# Altium schematic sheet ModelT_MainBoard.SchDoc
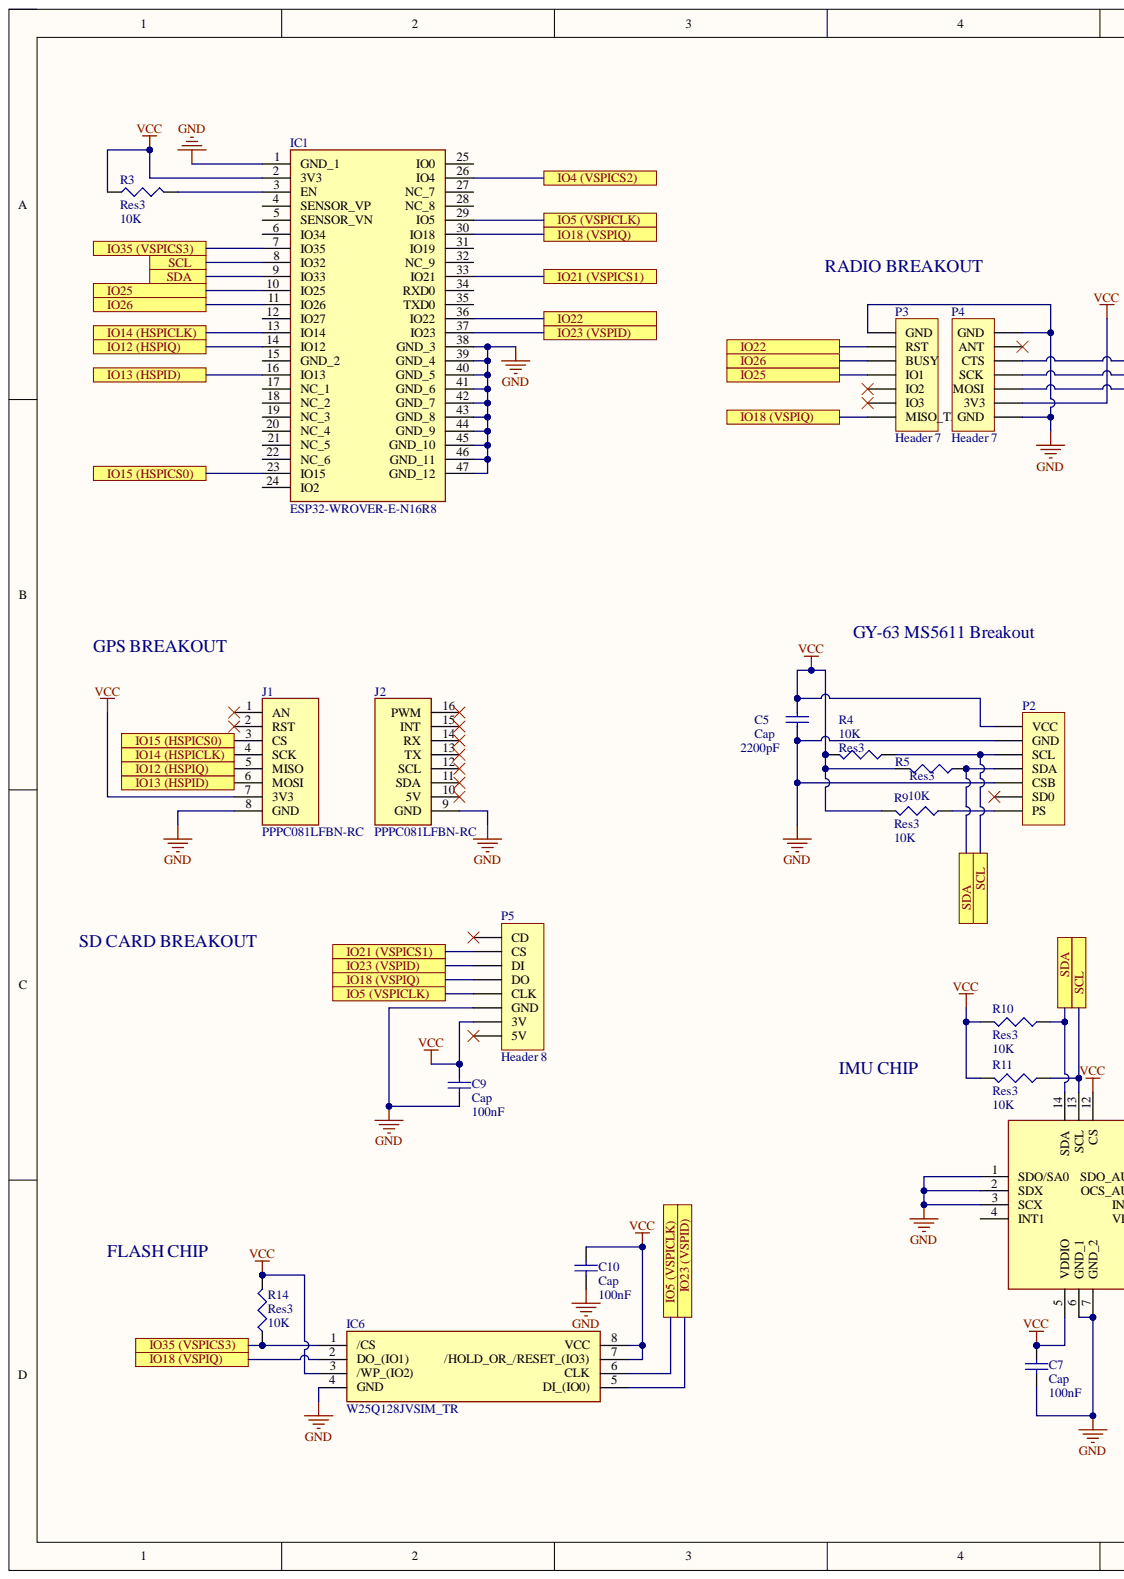
[diagram p0: ModelT_MainBoard.SchDoc, left]
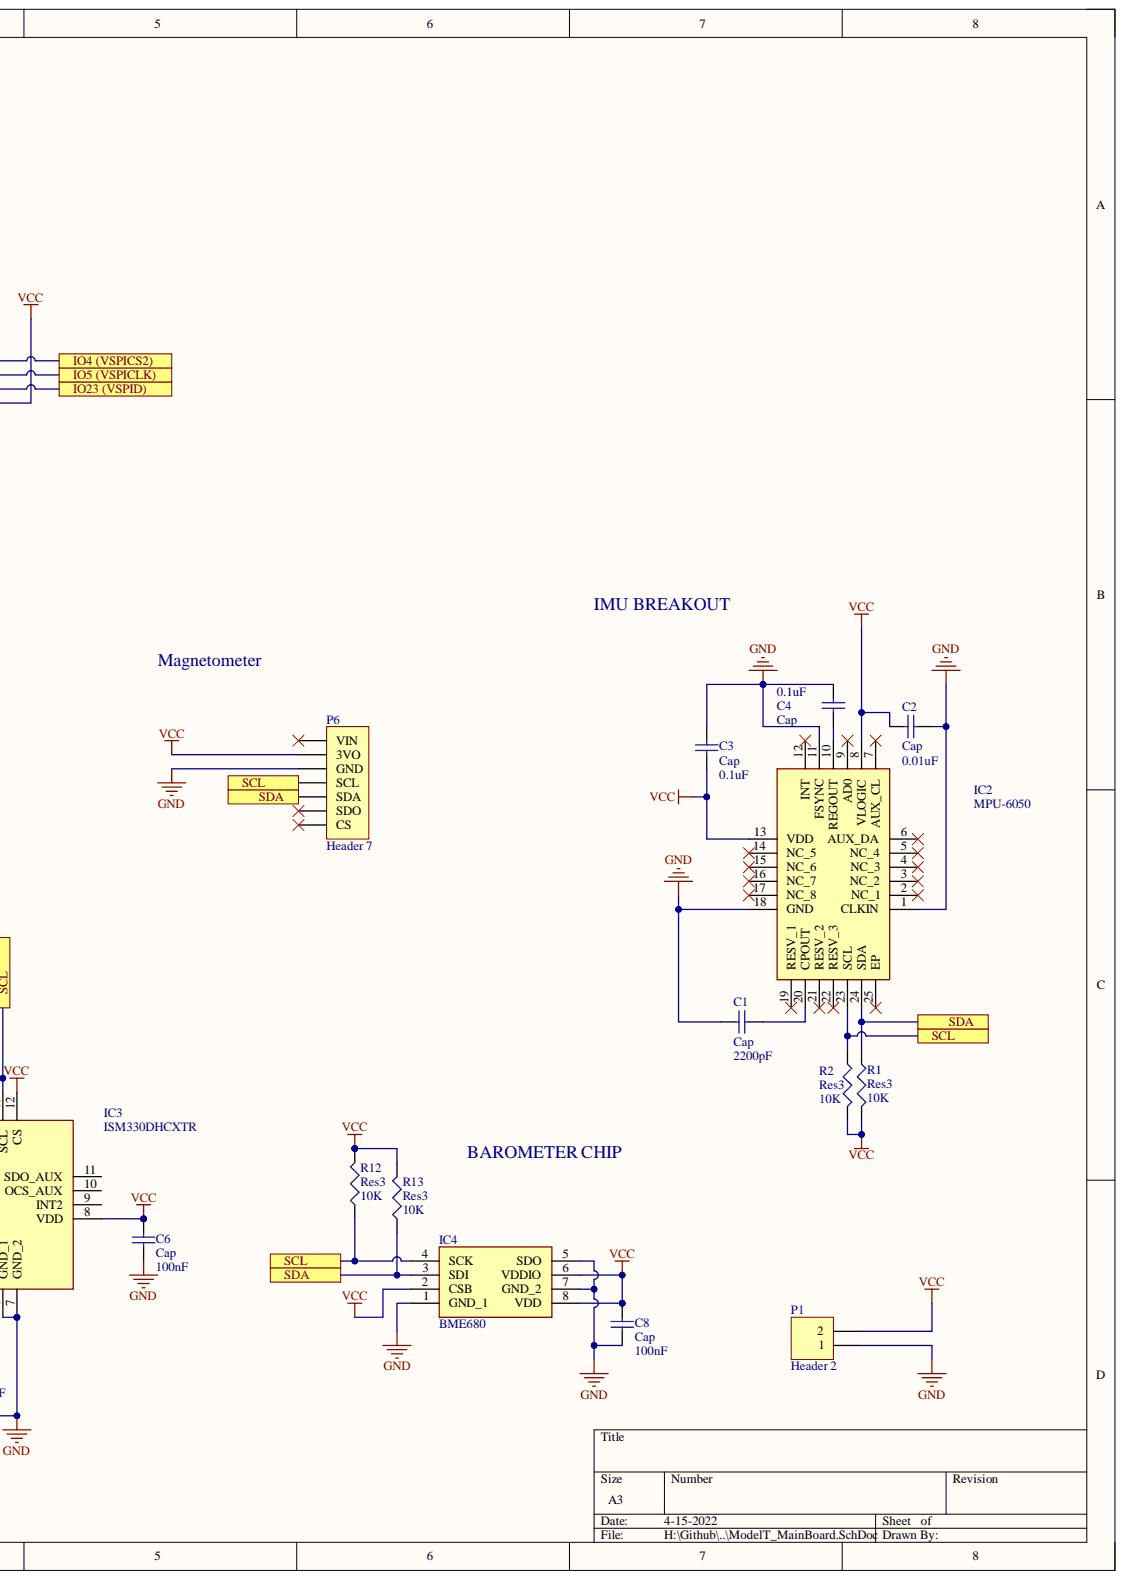
[diagram p1: ModelT_MainBoard.SchDoc, right]

## Parts
C1  Cap  value 2200pF  [RAD-0.3]
C2  Cap  value 0.01uF  [RAD-0.3]
C3  Cap  value 0.1uF  [RAD-0.3]
C4  Cap  value 0.1uF  [RAD-0.3]
C5  Cap  value 2200pF  [RAD-0.3]
C6  Cap  value 100nF  [RAD-0.3]
C7  Cap  value 100nF  [RAD-0.3]
C8  Cap  value 100nF  [RAD-0.3]
C9  Cap  value 100nF  [RAD-0.3]
C10  Cap  value 100nF  [RAD-0.3]
IC1  ESP32-WROVER-E-N16R8  [ESP32WROVEREN16R8]
IC2  MPU-6050  [MPU6050]
IC3  ISM330DHCXTR  [LGA-14(2.5X3X0.86)]
IC4  BME680  [BME680]
IC6  W25Q128JVSIM_TR  [SOIC127P790X216-8N]
J1  PPPC081LFBN-RC  [RHDR8W80P0X254_1X8_2082X254X850P]
J2  PPPC081LFBN-RC  [RHDR8W80P0X254_1X8_2082X254X850P]
P1  Header 2  [HDR1X2]
P2  GY-63 MS5611 Breakout  [HDR1X7]
P3  Header 7  [HDR1X7]
P4  Header 7  [HDR1X7]
P5  Header 8  [HDR1X8]
P6  Header 7  [HDR1X7]
R1  Res3  value 10K  [J1-0603]
R2  Res3  value 10K  [J1-0603]
R3  Res3  value 10K  [J1-0603]
R4  Res3  value 10K  [J1-0603]
R5  Res3  value 10K  [J1-0603]
R9  Res3  value 10K  [J1-0603]
R10  Res3  value 10K  [J1-0603]
R11  Res3  value 10K  [J1-0603]
R12  Res3  value 10K  [J1-0603]
R13  Res3  value 10K  [J1-0603]
R14  Res3  value 10K  [J1-0603]

## Ports
IO4 (VSPICS2), IO5 (VSPICLK), IO12 (HSPIQ), IO13 (HSPID), IO14 (HSPICLK), IO15 (HSPICS0), IO18 (VSPIQ), IO21 (VSPICS1), IO22, IO23 (VSPID), IO25, IO26, IO35 (VSPICS3), SCL, SDA

## Nets
GND: C1.2 C2.2 C3.1 C4.1 C5.2 C6.1 C7.1 C8.1 C9.1 C10.1 IC1.1(GND_1) IC1.38(GND_3) IC1.39(GND_4) IC1.40(GND_5) IC1.41(GND_6) IC1.42(GND_7) IC1.43(GND_8) IC1.44(GND_9) IC1.45(GND_10) IC1.46(GND_11) IC1.47(GND_12) IC2.1(CLKIN) IC2.11(FSYNC) IC2.18(GND) IC3.1(SDO/SA0) IC3.2(SDX) IC3.3(SCX) IC3.6(GND_1) IC3.7(GND_2) IC4.1(GND_1) IC4.5(SDO) IC4.7(GND_2) IC6.4(GND) J1.8(GND) J2.9(GND) P1.1 P2.2(GND) P2.5(CSB) P3.1(GND) P4.8(GND) P4.14(GND) P5.6(GND) P6.3(GND)
VCC: C2.1 C3.2 C5.1 C6.2 C7.2 C8.2 C9.2 C10.2 IC1.2(3V3) IC2.8(VLOGIC) IC2.13(VDD) IC3.5(VDDIO) IC3.8(VDD) IC3.12(CS) IC4.2(CSB) IC4.6(VDDIO) IC4.8(VDD) IC6.3(/WP_(IO2)) IC6.7(/HOLD_OR_/RESET_(IO3)) IC6.8(VCC) J1.7(3V3) P1.2 P2.1(VCC) P4.9(3V3) P5.7(3V) P6.2(3VO) R1.2 R2.2 R3.1 R4.2 R5.2 R9.2 R10.2 R11.2 R12.2 R13.2 R14.2
IO4 (VSPICS2): IC1.26(IO4) P4.12(CTS) ; port IO4 (VSPICS2)
IO5 (VSPICLK): IC1.29(IO5) IC6.6(CLK) P4.11(SCK) P5.5(CLK) ; port IO5 (VSPICLK)
IO12 (HSPIQ): IC1.14(IO12) J1.5(MISO) ; port IO12 (HSPIQ)
IO13 (HSPID): IC1.16(IO13) J1.6(MOSI) ; port IO13 (HSPID)
IO14 (HSPICLK): IC1.13(IO14) J1.4(SCK) ; port IO14 (HSPICLK)
IO15 (HSPICS0): IC1.23(IO15) J1.3(CS) ; port IO15 (HSPICS0)
IO18 (VSPIQ): IC1.30(IO18) IC6.2(DO_(IO1)) P3.7 P5.4(DO) ; port IO18 (VSPIQ)
IO21 (VSPICS1): IC1.33(IO21) P5.2(CS) ; port IO21 (VSPICS1)
IO22: IC1.36(IO22) P3.2(RST) ; port IO22
IO23 (VSPID): IC1.37(IO23) IC6.5(DI_(IO0)) P4.10(MOSI) P5.3(DI) ; port IO23 (VSPID)
IO25: IC1.10(IO25) P3.4(IO1) ; port IO25
IO26: IC1.11(IO26) P3.3 ; port IO26
IO35 (VSPICS3): IC1.7(IO35) IC6.1(/CS) R14.1 ; port IO35 (VSPICS3)
SCL: IC1.8(IO32) IC2.23(SCL) IC3.13(SCL) IC4.4(SCK) P2.3(SCL) P6.4(SCL) R2.1 R4.1 R11.1 R12.1 ; port SCL
SDA: IC1.9(IO33) IC2.24(SDA) IC3.14(SDA) IC4.3(SDI) P2.4(SDA) P6.5(SDA) R1.1 R5.1 R10.1 R13.1 ; port SDA
NetC1_1: C1.1 IC2.20(CPOUT)
NetC4_2: C4.2 IC2.10(REGOUT)
NetIC1_3: IC1.3(EN) R3.2
NetP2_7: P2.7(PS) R9.1

Not connected: IC1 4(SENSOR_VP) 5(SENSOR_VN) 6(IO34) 12(IO27) 15(GND_2) 17(NC_1) 18(NC_2) 19(NC_3) 20(NC_4) 21(NC_5) 22(NC_6) 24(IO2) 25(IO0) 27(NC_7) 28(NC_8) 31(IO19) 32(NC_9) 34(RXD0) 35(TXD0); IC2 2(NC_1) 3(NC_2) 4(NC_3) 5(NC_4) 6(AUX_DA) 7(AUX_CL) 9(AD0) 12(INT) 14(NC_5) 15(NC_6) 16(NC_7) 17(NC_8) 19(RESV_1) 21(RESV_2) 22(RESV_3) 25(EP); IC3 4(INT1) 9(INT2) 10(OCS_AUX) 11(SDO_AUX); J1 1(AN) 2(RST); J2 10(5V) 11(SDA) 12(SCL) 13(TX) 14(RX) 15(INT) 16(PWM); P2 6(SD0); P3 5(IO2) 6(IO3); P4 13(ANT); P5 1(CD) 8(5V); P6 1(VIN) 6(SDO) 7(CS)

## Library data of the parts
C1 C3-C10 = Cap: Capacitor; Component Kind: Standard; LatestRevisionDate: 17-Jul-2002; LatestRevisionNote: Re-released for DXP Platform.; Library Name: Miscellaneous Devices.IntLib; PackageDescription: Radial Cap, Thru-Hole; 2 Leads; 0.3 in Pin Spacing; PackageReference: RAD-0.3; Published: 8-Jun-2000; Signal Integrity: Cap; Simulation: CAP; Publisher: Altium Limited
C2 = Cap: Capacitor; Value: 0.01uF; Component Kind: Standard; LatestRevisionDate: 17-Jul-2002; LatestRevisionNote: Re-released for DXP Platform.; Library Name: Miscellaneous Devices.IntLib; PackageDescription: Radial Cap, Thru-Hole; 2 Leads; 0.3 in Pin Spacing; PackageReference: RAD-0.3; Published: 8-Jun-2000; Signal Integrity: Cap; Simulation: CAP; Publisher: Altium Limited
IC1 = ESP32-WROVER-E-N16R8: Integrated Circuit; Manufacturer_Name: Espressif Systems; Component Kind: Standard; Datasheet Link: https://www.espressif.com/sites/default/files/documentation/esp32-wrover-e_esp32-wrover-ie_datasheet_en.pdf; Height: 3.45mm; Manufacturer_Part_Number: ESP32-WROVER-E-N16R8; Mouser Part Number: 356-ESP32WRVE22864PC; Mouser Price/Stock: https://www.mouser.co.uk/ProductDetail/Espressif-Systems/ESP32-WROVER-E-N16R8?qs=Li%252BoUPsLEnsfUZ%252By2eNF6g%3D%3D
IC2 = MPU-6050: Integrated Circuit; Manufacturer_Name: TDK; Arrow Part Number: MPU-6050; Arrow Price/Stock: https://www.arrow.com/en/products/mpu-6050/invensense?region=nac; Component Kind: Standard; Datasheet Link: https://product.tdk.com/system/files/dam/doc/product/sensor/mortion-inertial/imu/data_sheet/mpu-6000-datasheet1.pdf; Height: 0.95mm; Manufacturer_Part_Number: MPU-6050; Mouser Part Number: 410-MPU-6050; Mouser Price/Stock: https://www.mouser.co.uk/ProductDetail/TDK-InvenSense/MPU-6050?qs=u4fy%2FsgLU9O14B5JgyQFvg%3D%3D
IC3 = ISM330DHCXTR: Integrated Circuit; Manufacturer_Name: STMicroelectronics; Arrow Part Number: ISM330DHCXTR; Arrow Price/Stock: https://www.arrow.com/en/products/ism330dhcxtr/stmicroelectronics?region=nac; Component Kind: Standard; Datasheet Link: https://www.st.com/resource/en/datasheet/ism330dhcx.pdf; Manufacturer_Part_Number: ISM330DHCXTR; Mouser Part Number: 511-ISM330DHCXTR; Mouser Price/Stock: https://www.mouser.co.uk/ProductDetail/STMicroelectronics/ISM330DHCXTR?qs=%252B6g0mu59x7Jv2Xps2dM6Xw%3D%3D
IC4 = BME680: Integrated Circuit; Manufacturer_Name: Bosch Sensortec; Arrow Part Number: BME680; Arrow Price/Stock: https://www.arrow.com/en/products/bme680/bosch?region=nac; Component Kind: Standard; Datasheet Link: https://datasheet.datasheetarchive.com/originals/distributors/DKDS-24/462907.pdf; Manufacturer_Part_Number: BME680; Mouser Part Number: 262-BME680; Mouser Price/Stock: https://www.mouser.co.uk/ProductDetail/Bosch-Sensortec/BME680?qs=v271MhAjFHjo0yA%2FC4OnDQ%3D%3D
IC6 = W25Q128JVSIM_TR: Integrated Circuit; Manufacturer_Name: Winbond; Manufacturer_Part_Number: W25Q128JVSIM TR; Arrow Part Number: W25Q128JVSIM TR; Arrow Price/Stock: https://www.arrow.com/en/products/w25q128jvsimtr/winbond-electronics?region=nac; Component Kind: Standard; Datasheet Link: http://www.winbond.com/resource-files/w25q128jv%20dtr%20revb%2011042016.pdf; Height: 2.16mm; Mouser Part Number: 454-W25Q128JVSIMTR; Mouser Price/Stock: https://www.mouser.co.uk/ProductDetail/Winbond/W25Q128JVSIM-TR?qs=qSfuJ%252Bfl%2Fd6ji9IuruL6Zg%3D%3D
J1 J2 = PPPC081LFBN-RC: Connector; Manufacturer_Name: Sullins; Component Kind: Standard; Datasheet Link: https://drawings-pdf.s3.amazonaws.com/10492.pdf; Height: 8.5mm; Manufacturer_Part_Number: PPPC081LFBN-RC
P1 = Header 2: Header, 2-Pin; Component Kind: Standard; LatestRevisionDate: 17-Jul-2002; LatestRevisionNote: Re-released for DXP Platform.; Library Name: Miscellaneous Connectors.IntLib; Signal Integrity: Connector; Publisher: Altium Limited
P2-P4 P6 = Header 7: Header, 7-Pin; Component Kind: Standard; LatestRevisionDate: 17-Jul-2002; LatestRevisionNote: Re-released for DXP Platform.; Library Name: Miscellaneous Connectors.IntLib; Signal Integrity: Connector; Publisher: Altium Limited
P5 = Header 8: Header, 8-Pin; Component Kind: Standard; LatestRevisionDate: 17-Jul-2002; LatestRevisionNote: Re-released for DXP Platform.; Library Name: Miscellaneous Connectors.IntLib; Signal Integrity: Connector; Publisher: Altium Limited
R1-R4 R9 R11-R14 = Res3: Resistor; Component Kind: Standard; LatestRevisionDate: 29-May-2009; LatestRevisionNote: IPC-7351 Footprint Added.; Library Name: Miscellaneous Devices.IntLib; PackageDescription: Chip Resistor; PackageReference: J1-0603; Published: 8-Jun-2000; Signal Integrity: Res; Simulation: RESISTOR; Publisher: Altium Limited
R5 R10 = Res3: Resistor; Value: 10K; Component Kind: Standard; LatestRevisionDate: 29-May-2009; LatestRevisionNote: IPC-7351 Footprint Added.; Library Name: Miscellaneous Devices.IntLib; PackageDescription: Chip Resistor; PackageReference: J1-0603; Published: 8-Jun-2000; Signal Integrity: Res; Simulation: RESISTOR; Publisher: Altium Limited
